# Altium schematic sheet STM32F030.SchDoc
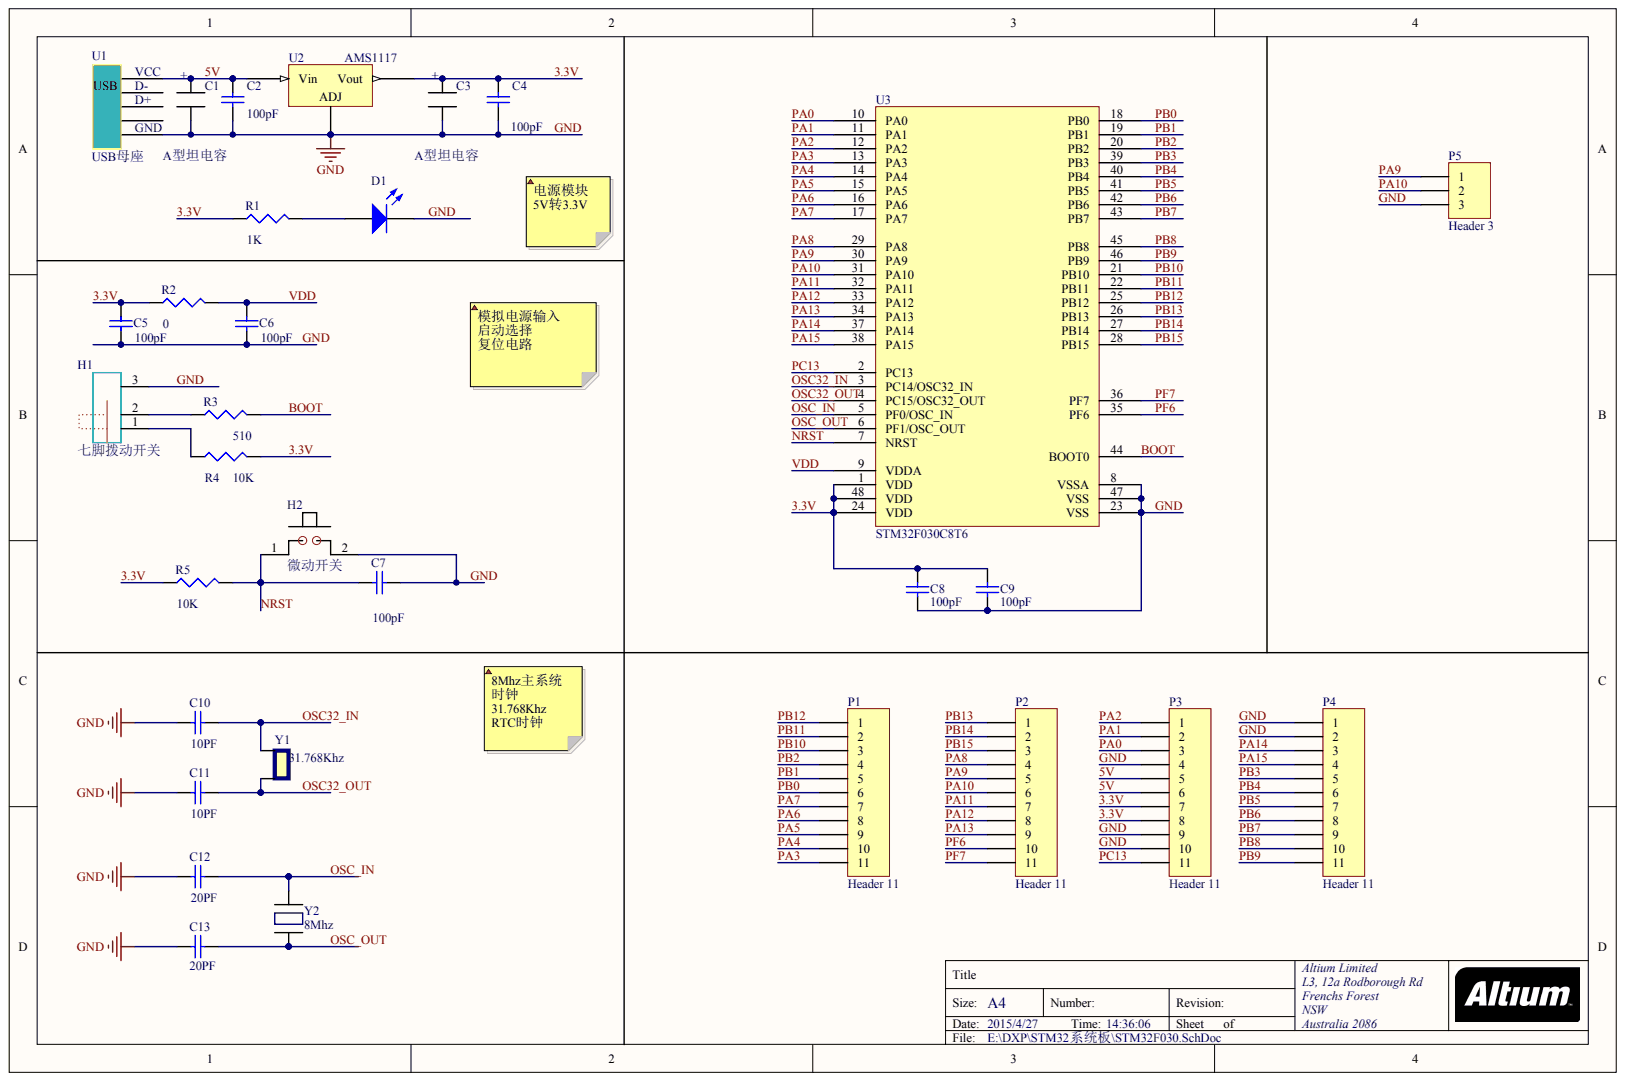
[diagram p0: STM32F030.SchDoc]

## Parts
C1  A型坦电容
C2  value 100pF  (hidden comment: 0.1UF)
C3  A型坦电容
C4  value 100pF  (hidden comment: 0.1UF)
C5  value 100pF  (hidden comment: 0.1UF)
C6  value 100pF  (hidden comment: 0.1UF)
C7  value 100pF  (hidden comment: 0.1UF)
C8  value 100pF  (hidden comment: 0.1UF)
C9  value 100pF  (hidden comment: 0.1UF)
C10  10PF
C11  10PF
C12  20PF
C13  20PF
D1  LED  (value not drawn)
H1  七脚拨动开关
H2  微动开关
P1  Header 11
P2  Header 11
P3  Header 11
P4  Header 11
P5  Header 3
R1  1K
R2  0
R3  510
R4  10K
R5  10K
U1  USB母座
U2  AMS1117
U3  STM32F030C8T6
Y1  31.768Khz
Y2  8Mhz

## Nets
3.3V: C3.2 C4.2 C5.2 C8.2 C9.2 P3.7 P3.8 R1.1 R2.1 R4.2 R5.1 U2.2 U3.1(VDD) U3.24(VDD) U3.48(VDD)
5V: C1.2 C2.2 P3.5 P3.6 U1.1 U2.3
BOOT: R3.2 U3.44(BOOT0)
GND: C1.1 C2.1 C3.1 C4.1 C5.1 C6.1 C7.2 C8.1 C9.1 C10.1 C11.1 C12.1 C13.1 D1.2 H1.3 H2.2 P3.4 P3.9 P3.10 P4.1 P4.2 P5.3 U1.5 U2.1 U3.8(VSSA) U3.23(VSS) U3.47(VSS)
NRST: C7.1 H2.1 R5.2 U3.7(NRST)
OSC32_IN: C10.2 U3.3(PC14/OSC32_IN) Y1.0
OSC32_OUT: C11.2 U3.4(PC15/OSC32_OUT) Y1.1
OSC_IN: C12.2 U3.5(PF0/OSC_IN) Y2.2
OSC_OUT: C13.2 U3.6(PF1/OSC_OUT) Y2.1
PA0: P3.3 U3.10(PA0)
PA1: P3.2 U3.11(PA1)
PA2: P3.1 U3.12(PA2)
PA3: P1.11 U3.13(PA3)
PA4: P1.10 U3.14(PA4)
PA5: P1.9 U3.15(PA5)
PA6: P1.8 U3.16(PA6)
PA7: P1.7 U3.17(PA7)
PA8: P2.4 U3.29(PA8)
PA9: P2.5 P5.1 U3.30(PA9)
PA10: P2.6 P5.2 U3.31(PA10)
PA11: P2.7 U3.32(PA11)
PA12: P2.8 U3.33(PA12)
PA13: P2.9 U3.34(PA13)
PA14: P4.3 U3.37(PA14)
PA15: P4.4 U3.38(PA15)
PB0: P1.6 U3.18(PB0)
PB1: P1.5 U3.19(PB1)
PB2: P1.4 U3.20(PB2)
PB3: P4.5 U3.39(PB3)
PB4: P4.6 U3.40(PB4)
PB5: P4.7 U3.41(PB5)
PB6: P4.8 U3.42(PB6)
PB7: P4.9 U3.43(PB7)
PB8: P4.10 U3.45(PB8)
PB9: P4.11 U3.46(PB9)
PB10: P1.3 U3.21(PB10)
PB11: P1.2 U3.22(PB11)
PB12: P1.1 U3.25(PB12)
PB13: P2.1 U3.26(PB13)
PB14: P2.2 U3.27(PB14)
PB15: P2.3 U3.28(PB15)
PC13: P3.11 U3.2(PC13)
PF6: P2.10 U3.35(PF6)
PF7: P2.11 U3.36(PF7)
VDD: C6.2 R2.2 U3.9(VDDA)
NetD1_1: D1.1 R1.2
NetH1_1: H1.1 R4.1
NetH1_2: H1.2 R3.1

Not connected: U1 2(USB) 3 4

## Library data of the parts
C1-C13 D1 H1 H2 P1-P5 R1-R5 U1-U3 Y1 Y2 = (no symbol name)
  C2 C4-C9: Published: 8-Jun-2000; LatestRevisionDate: 29-May-2009; LatestRevisionNote: IPC-7351 Footprint Added.; PackageReference: C1206; PackageDescription: Chip Capacitor
  C10 C11: Published: 8-Jun-2000; LatestRevisionDate: 29-May-2009; LatestRevisionNote: IPC-7351 Footprint Added.; PackageReference: C1206; PackageDescription: Chip Capacitor; Value: 10PF
  C12 C13: Published: 8-Jun-2000; LatestRevisionDate: 29-May-2009; LatestRevisionNote: IPC-7351 Footprint Added.; PackageReference: C1206; PackageDescription: Chip Capacitor; Value: 20PF
  D1: Published: 8-Jun-2000; LatestRevisionDate: 17-Jul-2002; LatestRevisionNote: Re-released for DXP Platform.; PackageVersion: Feb-2002; PackageReference: 3.2X1.6X1.1; PackageDescription: SM LED; 2 Flat Leads
  P1-P5: LatestRevisionDate: 17-Jul-2002; LatestRevisionNote: Re-released for DXP Platform.
  R1: Published: 8-Jun-2000; LatestRevisionDate: 29-May-2009; LatestRevisionNote: IPC-7351 Footprint Added.; PackageReference: J1-0603; PackageDescription: Chip Resistor; Value: 1K
  R2: Published: 8-Jun-2000; LatestRevisionDate: 29-May-2009; LatestRevisionNote: IPC-7351 Footprint Added.; PackageReference: J1-0603; PackageDescription: Chip Resistor; Value: 0
  R3: Published: 8-Jun-2000; LatestRevisionDate: 29-May-2009; LatestRevisionNote: IPC-7351 Footprint Added.; PackageReference: J1-0603; PackageDescription: Chip Resistor; Value: 510
  R4 R5: Published: 8-Jun-2000; LatestRevisionDate: 29-May-2009; LatestRevisionNote: IPC-7351 Footprint Added.; PackageReference: J1-0603; PackageDescription: Chip Resistor; Value: 10K
  C2 C4-C13 D1 P1-P5 R1-R5: Publisher: Altium Limited
